# Altium schematic sheet Sheet1_Therm.SchDoc
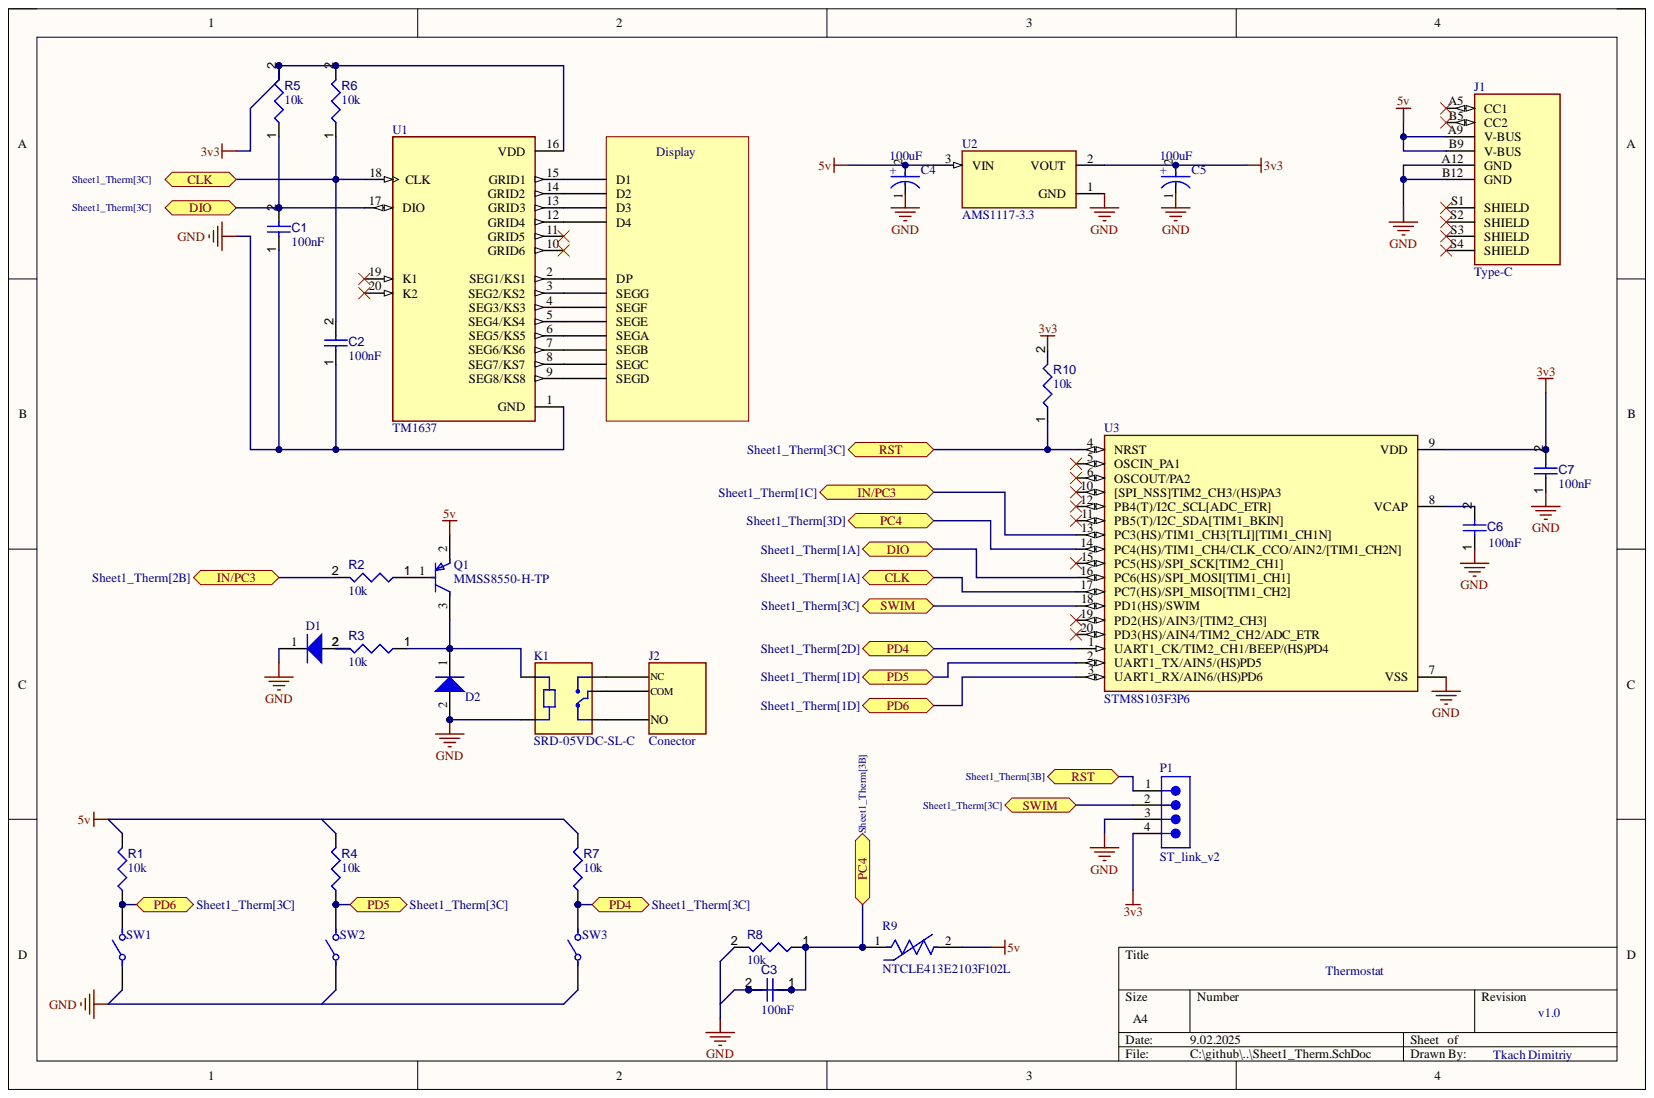
[diagram p0: Sheet1_Therm.SchDoc]

## Parts
C1  value 100nF  [CAPC1608X90X40NL10T20]  (hidden comment: Capacitor 100 nF +/-10% 50 V 0603)
C2  value 100nF  [CAPC1608X90X40NL10T20]  (hidden comment: Capacitor 100 nF +/-10% 50 V 0603)
C3  value 100nF  [CAPC1608X90X40NL10T20]  (hidden comment: Capacitor 100 nF +/-10% 50 V 0603)
C4  value 100uF  Capacitance: 100uF  [FP-EEU-FR1H101B-MFG]  (hidden comment: EEU-FR1H101B)
C5  value 100uF  Capacitance: 100uF  [FP-EEU-FR1H101B-MFG]  (hidden comment: EEU-FR1H101B)
C6  value 100nF  [CAPC1608X90X40NL10T20]  (hidden comment: Capacitor 100 nF +/-10% 50 V 0603)
C7  value 100nF  [CAPC1608X90X40NL10T20]  (hidden comment: Capacitor 100 nF +/-10% 50 V 0603)
D1  BAT54HT1G  [ONSC-SOD-323-2-477-02_V]  (value not drawn)
D2  BAT54HT1G  [ONSC-SOD-323-2-477-02_V]  (value not drawn)
Display  Component_1  (value not drawn)
J1  Type-C  [CUI_UJC-HP-3-SMT-TR]
J2  Conector
K1  SRD-05VDC-SL-C  [RELAY_SRD-05VDC-SL-C]
P1  ST_link_v2  [61300411021]
Q1  MMSS8550-H-TP  [FP-SOT-23-IPC_B]
R1  value 10k  [RESC1005X40X25ML05T05]  (hidden comment: Resistor 10k +/-1% 0402 63 mW)
R2  value 10k  [RESC1005X40X25ML05T05]  (hidden comment: Resistor 10k +/-1% 0402 63 mW)
R3  value 10k  [RESC1005X40X25ML05T05]  (hidden comment: Resistor 10k +/-1% 0402 63 mW)
R4  value 10k  [RESC1005X40X25ML05T05]  (hidden comment: Resistor 10k +/-1% 0402 63 mW)
R5  value 10k  [RESC1005X40X25ML05T05]  (hidden comment: Resistor 10k +/-1% 0402 63 mW)
R6  value 10k  [RESC1005X40X25ML05T05]  (hidden comment: Resistor 10k +/-1% 0402 63 mW)
R7  value 10k  [RESC1005X40X25ML05T05]  (hidden comment: Resistor 10k +/-1% 0402 63 mW)
R8  value 10k  [RESC1005X40X25ML05T05]  (hidden comment: Resistor 10k +/-1% 0402 63 mW)
R9  NTCLE413E2103F102L  [FP-NTCLE413E2103F102L-MFG]
R10  value 10k  [RESC1005X40X25ML05T05]  (hidden comment: Resistor 10k +/-1% 0402 63 mW)
SW1  EVQ-QJJ05Q  [FP-EVQ-QJJ05Q-MFG]  (value not drawn)
SW2  EVQ-QJJ05Q  [FP-EVQ-QJJ05Q-MFG]  (value not drawn)
SW3  EVQ-QJJ05Q  [FP-EVQ-QJJ05Q-MFG]  (value not drawn)
U1  TM1637  [DIP778W43P254L2612H431Q20N]
U2  AMS1117-3.3  [SOT229P700X180-4N]
U3  STM8S103F3P6  [SOP65P640X120-20N]

## Ports
CLK, DIO, IN/PC3, PC4, PD4, PD5, PD6, RST, SWIM

## Nets
3v3: C5.2 C7.2 P1.4 R5.2 R6.2 R10.2 U1.16(VDD) U2.2 U3.9(VDD)
5v: C4.2 J1.A9(V-BUS) J1.B9(V-BUS) Q1.2 R9.2 U2.3
GND: C1.1 C2.1 C4.1 C5.1 C6.1 C7.1 D1.1 D2.2 J1.A12(GND) J1.B12(GND) K1.A2 P1.3 U1.1(GND) U2.1 U3.7(VSS)
CLK: C2.2 R6.1 U1.18(CLK) U3.17(PC7(HS)/SPI_MISO[TIM1_CH2]) ; port CLK
DIO: C1.2 R5.1 U1.17(DIO) U3.16(PC6(HS)/SPI_MOSI[TIM1_CH1]) ; port DIO
IN/PC3: R2.2 U3.13(PC3(HS)/TIM1_CH3[TLI][TIM1_CH1N]) ; port IN/PC3
PC4: C3.1 C3.2 R8.1 R9.1 U3.14(PC4(HS)/TIM1_CH4/CLK_CCO/AIN2/[TIM1_CH2N]) ; port PC4
PD4: R7.1 SW3.B U3.1(UART1_CK/TIM2_CH1/BEEP/(HS)PD4) ; port PD4
PD5: R4.1 SW2.B U3.2(UART1_TX/AIN5/(HS)PD5) ; port PD5
PD6: R1.1 SW1.B U3.3(UART1_RX/AIN6/(HS)PD6) ; port PD6
RST: P1.1 R10.1 U3.4(NRST) ; port RST
SWIM: P1.2 U3.18(PD1(HS)/SWIM) ; port SWIM
NetC6_2: C6.2 U3.8(VCAP)
NetD1_2: D1.2 R3.2
NetD2_1: D2.1 K1.A1 Q1.3 R3.1
NetDisplay_D1: Display.D1 U1.15(GRID1)
NetDisplay_D2: Display.D2 U1.14(GRID2)
NetDisplay_D3: Display.D3 U1.13(GRID3)
NetDisplay_D4: Display.D4 U1.12(GRID4)
NetDisplay_DP: Display.DP U1.2(SEG1/KS1)
NetDisplay_SEGA: Display.SEGA U1.6(SEG5/KS5)
NetDisplay_SEGB: Display.SEGB U1.7(SEG6/KS6)
NetDisplay_SEGC: Display.SEGC U1.8(SEG7/KS7)
NetDisplay_SEGD: Display.SEGD U1.9(SEG8/KS8)
NetDisplay_SEGE: Display.SEGE U1.5(SEG4/KS4)
NetDisplay_SEGF: Display.SEGF U1.4(SEG3/KS3)
NetDisplay_SEGG: Display.SEGG U1.3(SEG2/KS2)
NetJ2_COM: J2.COM K1.COM
NetJ2_NC: J2.NC K1.NO
NetJ2_NO: J2.NO K1.NC
NetQ1_1: Q1.1 R2.1

Not connected: J1 A5(CC1) B5(CC2) S1(SHIELD) S2(SHIELD) S3(SHIELD) S4(SHIELD); R1 2; R4 2; R7 2; R8 2; SW1 A; SW2 A; SW3 A; U1 10(GRID6) 11(GRID5) 19(K1) 20(K2); U3 5(OSCIN_PA1) 6(OSCOUT/PA2) 10([SPI_NSS]TIM2_CH3/(HS)PA3) 11(PB5(T)/I2C_SDA[TIM1_BKIN]) 12(PB4(T)/I2C_SCL[ADC_ETR]) 15(PC5(HS)/SPI_SCK[TIM2_CH1]) 19(PD2(HS)/AIN3/[TIM2_CH3]) 20(PD3(HS)/AIN4/TIM2_CH2/ADC_ETR)

## Library data of the parts
C1-C3 C6 C7 = CMP-001-00017-6: Chip Capacitor, 100 nF, +/- 10%, 50 V, 0603 (1608 Metric); Capacitor Type: Ceramic; Voltage Rating: 50V; Case/Package: 0603; Component Kind: Standard; Max Operating Temperature: 125°C; Min Operating Temperature: -55°C; Mounting Technology: SM; Pins: 2; RoHS Compliant: True; Simulation: C_Capacitor_Default; Tolerance: 10%; Library Name: Дімітрій Ткач
C4 C5 = CMP-05427-000020-1: CAP ALUM 100UF 20% 50V RADIAL; ESR (Equivalent Series Resistance): 61mR; Lead Diameter: 0.6mm; Life (Hours): 6000Hour; Ripple Current: 870mA; Case/Package: Radial; Component Kind: Standard; Contact Plating: Tin; Diameter: 8mm; Features: General Purpose; Height: 11.5mm; Height - Seated (Max): 0.512inch; Impedance: 61mR; Lead Free: Lead Free; Lead Pitch: 5mm; Length: 11.5mm; Library Name: Altium Content Vault; Max Operating Temperature: 105°C; Min Operating Temperature: -40°C; Mount: Through Hole; Package Quantity: 1; Packaging: Tape and Reel; Radiation Hardening: No; REACH SVHC: No SVHC; RoHS Compliant: Yes; Series: FR; Simulation: C_Capacitor_Default; Termination: Radial; Tolerance: 20%; Voltage Rating: 50V; Voltage Rating (DC): 50V
D1 D2 = CMP-1055-00462-1: Schottky Barrier Diode, 2-Pin SOD-323, Pb-Free, Tape and Reel; Manufacturer: On Semiconductor; Configuration: Single; IFSM Max (A): 0.6; trr Max (ns): 5; Component Kind: Standard; ComponentLink1Description: Manufacturer URL; ComponentLink1URL: http://www.onsemi.com/; ComponentLink2Description: Datasheet; ComponentLink2URL: http://www.onsemi.cn/pub_link/Collateral/BAT54HT1-D.PDF; ComponentLink3Description: Package Specification; ComponentLink3URL: http://www.onsemi.com/pub_link/Collateral/477-02.PDF.1; DatasheetVersion: Rev. 6; Library Name: Altium Content Vault; Mounting Technology: Surface Mount; PackageDescription: 2-Pin SOD, Body 1.7 x 1.25 mm; PackageReference: SOD-323-2-477-02; PackageVersion: Rev. H, 03/2007; Packing: Tape and Reel; PartNumber: BAT54HT1G; RoHS: TRUE
J1 = UJC-HP-3-SMT-TR: Availability: Unavailable; Component Kind: Standard; Library Name: UJC-HP-3-SMT-TR.IntLib; MP: UJC-HP-3-SMT-TR; Package: None; Price: None
J2 = Component_1: Component Kind: Standard; Library Name: CON.SchLib
K1 = CMP-013-000000-1: 5V Trigger Relay Module For Arduino And Raspberry Pi 5V Trigger Relay Module For Arduino And Raspberry Pi; MF: Songle Relay; Availability: Not in stock; Check_prices: https://www.snapeda.com/parts/SRD-05VDC-SL-C/Songle+Relay/view-part/?ref=eda; Component Kind: Standard; Component Type: Standard; Design Item ID: SRD-05VDC-SL-C; MP: SRD-05VDC-SL-C; Package: NON STANDARD-5 Songle Relay; Pin Count: 5; Price: None; SnapEDA_Link: https://www.snapeda.com/parts/SRD-05VDC-SL-C/Songle+Relay/view-part/?ref=snap; Source: SRD-05VDC-SL-C.SchLib; Library Name: Дімітрій Ткач
P1 = CMP-1502-01063-3: NexarPartId: 31153320; Connector Type: Male Pin; Contact Resistance: 20mΩ; Current Rating: 3A; Gender: Male; Number of Contacts: 4; Operating Supply Voltage: 250V; Pitch: 2.54mm; Color: Black; Component Kind: Standard; Contact Material: Copper; Contact Mating Length: 5.9944mm; Contact Plating: Gold; Lead Pitch: 2.54mm; Library Name: Altium Content Vault; Mount: Through Hole; Number of Pins: 4; Number of Positions: 4; Number of Rows: 1; REACH SVHC: Unknown; RoHS: Compliant; Termination: Solder
Q1 = CMP-56214-000002-1: TRANS PNP 25V 1.5A SOT23; Collector Base Voltage (VCBO): 40V; Collector Emitter Saturation Voltage: 500mV; Element Configuration: Single; Emitter Base Voltage (VEBO): 1.6V; Gain Bandwidth Product: 100MHz; hFE Min: 40; Max Power Dissipation: 625mW; Case/Package: SOT-23; Collector Emitter Breakdown Voltage: 25V; Collector Emitter Voltage (VCEO): 500mV; Component Kind: Standard; Lead Free: Lead Free; Library Name: Altium Content Vault; Max Breakdown Voltage: 25V; Max Collector Current: 1.5A; Max Operating Temperature: 150°C; Min Operating Temperature: -55°C; Mount: Surface Mount; Package Quantity: 1; Packaging: Tape and Reel; Polarity: PNP; Transition Frequency: 100MHz
R1-R8 R10 = CMP-009-00019-5: Chip Resistor, 10 KOhm, +/- 1%, 63 mW, -55 to 155 degC, 0402 (1005 Metric); Power: 63mW; Voltage Rating: 50V; Case/Package: 0402; Component Kind: Standard; Max Operating Temperature: 155°C; Min Operating Temperature: -55°C; Mounting Technology: SM; Pins: 2; RoHS Compliant: True; Simulation: R_Resistor_Default; Tolerance: 1%; Library Name: Дімітрій Ткач
R9 = CMP-02404-000007-1: THERMISTOR NTC 10KOHM 3435K BEAD; Accuracy: 1%; Lead Diameter: 1mm; Max Power Dissipation: 250mW; Resistance: 10kR; Value: 10kR; Component Kind: Standard; Library Name: Altium Content Vault; Max Operating Temperature: 105°C; Min Operating Temperature: -40°C; Mount: Through Hole; Number of Pins: 2; Package Quantity: 100; Packaging: Bulk; Radiation Hardening: No; Resistance Tolerance: 1%; RoHS Compliant: Yes; Simulation: R_Resistor_Default; Termination: Through Hole; Tolerance: 1%
SW1-SW3 = CMP-00287-56250562-1: EVQ-QJJ05Q Switch Tactile N.O. SPST Round Button PC Pins 0.005A 12VDC 0.8N Thru-Hole Radial T/R; Actuator Length: 1.5mm; Contact Current Rating: 5mA; Contact Resistance: 200mΩ; Electrical Life: 1Mcycles; Illumination Type: Illuminated, Non-Illuminated; Max Voltage Rating (DC): 12V; Operating Force: 800mN; Switch Function: Off-Mom; Switch Travel: 1mm; Case/Package: Axial; Component Kind: Standard; Contact Plating: Silver; Current Rating: 5mA; Height: 5mm; Lead Free: Lead Free; Length: 8mm; Library Name: Altium Content Vault; Life (Cycles): 1Mcycles; Max Operating Temperature: 70°C; Mechanical Life: 1Mcycles; Min Operating Temperature: -20°C; Mount: Through Hole; NexarPartId: 56250562; Orientation: Straight; Packaging: Tape & Box (TB); Radiation Hardening: No; REACH SVHC: No SVHC; RoHS: Compliant; Termination: PC Pin; Throw Configuration: SPST; Voltage Rating (DC): 12V; Width: 8mm
U1 = TM1637: LED Drive Control Special Circuit; MF: Titan Micro Electronics; Check_prices: https://www.snapeda.com/parts/TM1637/Titan+Micro+Electronics/view-part/?ref=eda; Component Kind: Standard; Library Name: TM1637.IntLib; MP: TM1637; Package: None; SnapEDA_Link: https://www.snapeda.com/parts/TM1637/Titan+Micro+Electronics/view-part/?ref=snap
U2 = CMP-031-00006-2: Linear Voltage Regulator IC Positive Fixed 1 Output 1A SOT-223-3L; MF: UMW; Case/Package: SOT-223-3L UMW; Check_prices: https://www.snapeda.com/parts/AMS1117-3.3/UMW/view-part/?ref=eda; Component Kind: Standard; Component Type: Standard; Design Item ID: AMS1117-3.3; MP: AMS1117-3.3; Pins: 3; SnapEDA_Link: https://www.snapeda.com/parts/AMS1117-3.3/UMW/view-part/?ref=snap; Source: AMS1117-3.3.SchLib; Library Name: Дімітрій Ткач
U3 = STM8S103F3P6: STM8 series Microcontroller IC 8-Bit 16MHz 8KB (8K x 8) FLASH 20-TSSOP; MF: STMicroelectronics; Check_prices: https://www.snapeda.com/parts/STM8S103F3P6/STMicroelectronics/view-part/?ref=eda; Component Kind: Standard; Library Name: STM8S103F3P6.IntLib; MP: STM8S103F3P6; Package: TSSOP-20 STMicroelectronics; SnapEDA_Link: https://www.snapeda.com/parts/STM8S103F3P6/STMicroelectronics/view-part/?ref=snap
Display = Component_1: Component Kind: Standard; Library Name: DISPLAY.SchLib
